# Altium schematic sheet MODEM_R2_1.SchDoc
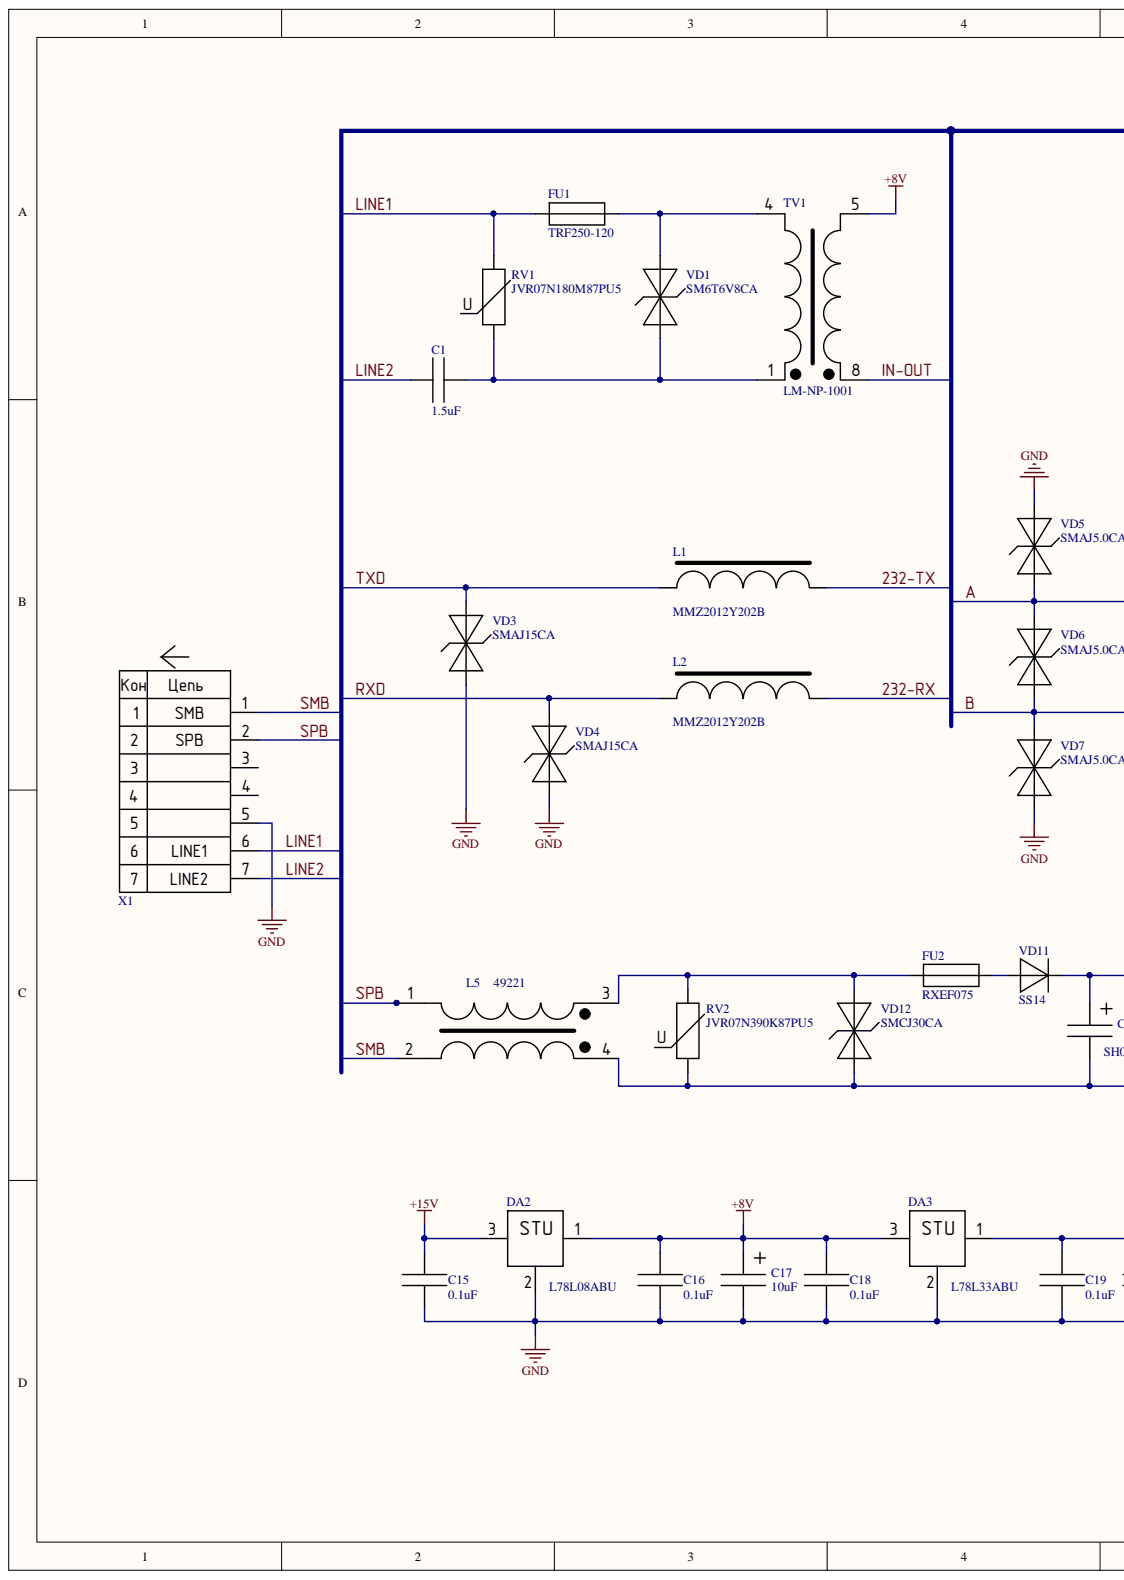
[diagram p0: MODEM_R2_1.SchDoc, left]
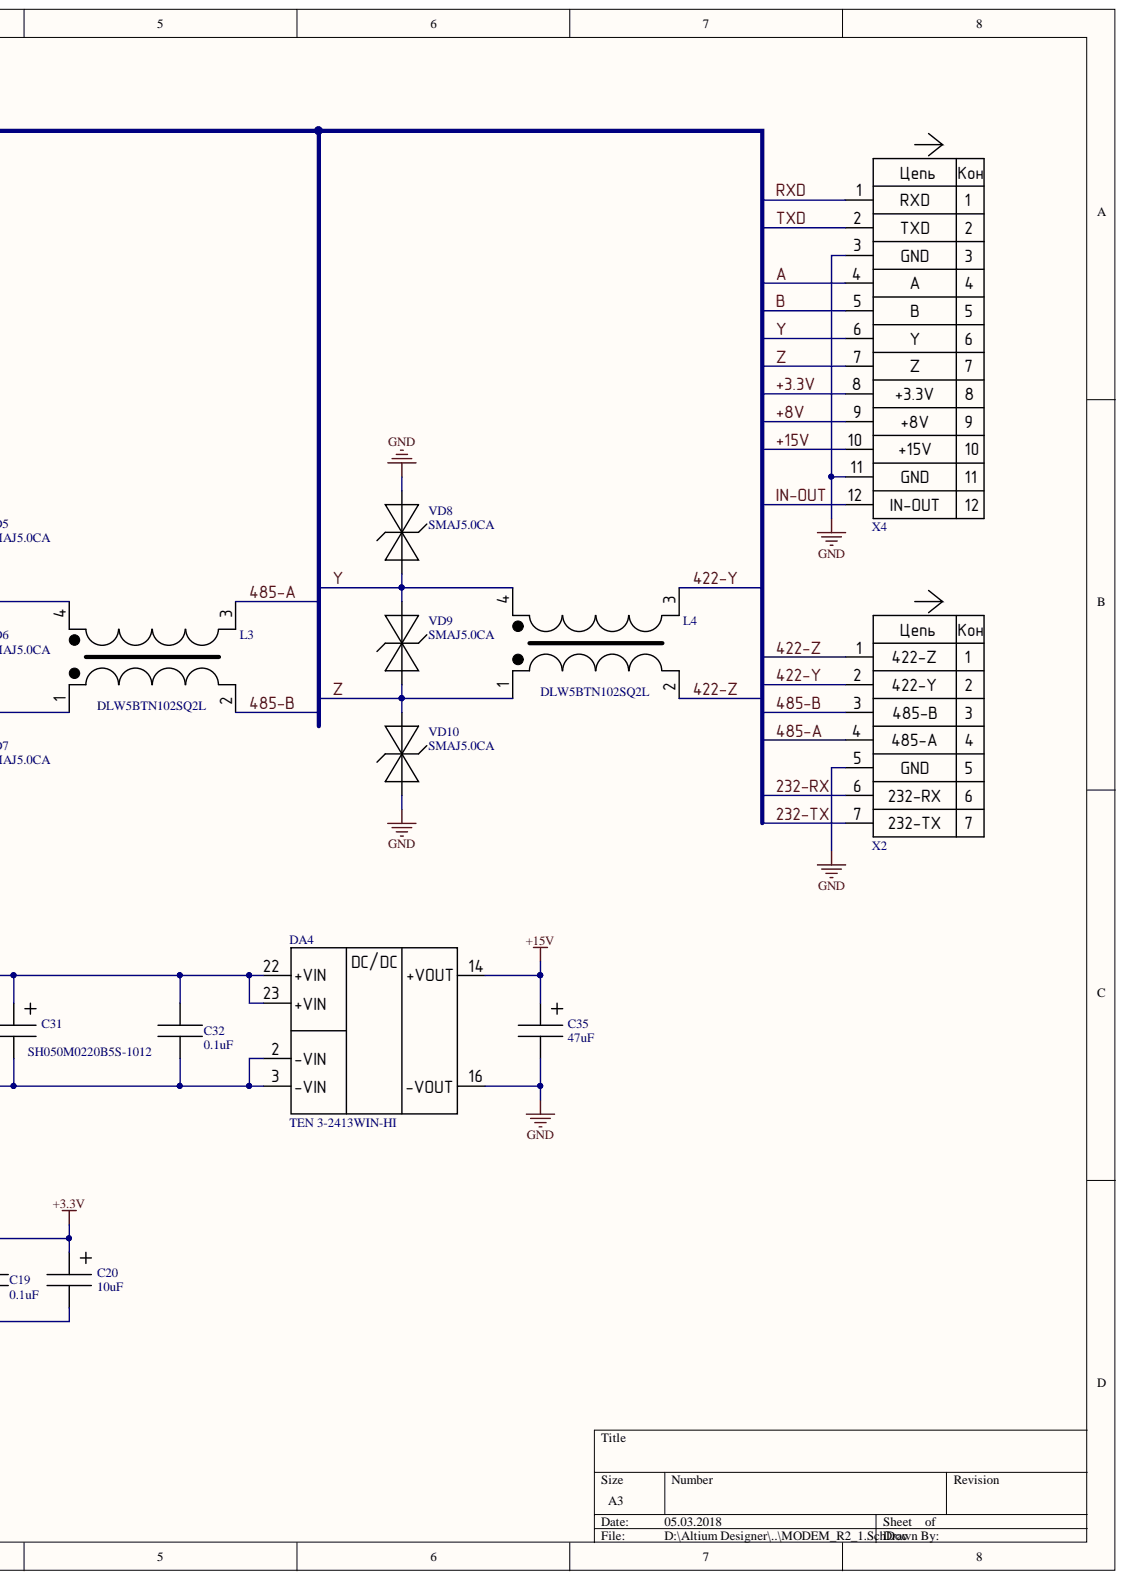
[diagram p1: MODEM_R2_1.SchDoc, right]

## Parts
C1  1.5uF  [TS02-22.5-h]
C15  0.1uF  [CC0805]
C16  0.1uF  [CC0805]
C17  10uF  [SMB]
C18  0.1uF  [CC0805]
C19  0.1uF  [CC0805]
C20  10uF  [SMB]
C31  SH050M0220B5S-1012  [SH050-1019]
C32  0.1uF  [CC0805]
C35  47uF  [SMD]
DA2  L78L08ABU  [SOT-89]
DA3  L78L33ABU  [SOT-89]
DA4  TEN 3-2413WIN-HI  [TEN3-2412WIN]
FU1  TRF250-120  [TRF250-120]
FU2  RXEF075  [RXEF075]
L1  MMZ2012Y202B  [MMZ2012Y202B]
L2  MMZ2012Y202B  [MMZ2012Y202B]
L3  DLW5BTN102SQ2L  [DLW5BTN]
L4  DLW5BTN102SQ2L  [DLW5BTN]
L5  49221  [49221]
RV1  JVR07N180M87PU5  [JVR07N330]
RV2  JVR07N390K87PU5  [JVR07N330]
TV1  LM-NP-1001  [LM-NP-1001]
VD1  SM6T6V8CA  [SM6T6V8CA]
VD3  SMAJ15CA  [SMAJ6.0CA]
VD4  SMAJ15CA  [SMAJ6.0CA]
VD5  SMAJ5.0CA  [SMAJ6.0CA]
VD6  SMAJ5.0CA  [SMAJ6.0CA]
VD7  SMAJ5.0CA  [SMAJ6.0CA]
VD8  SMAJ5.0CA  [SMAJ6.0CA]
VD9  SMAJ5.0CA  [SMAJ6.0CA]
VD10  SMAJ5.0CA  [SMAJ6.0CA]
VD11  SS14  [SS14]
VD12  SMCJ30CA  [SMCJ28A]
X1  8113_S/07  [8113_S/07]  (value not drawn)
X2  8113_S/07  [8113_S/07]  (value not drawn)
X4  PLS-12  [PLS-12]  (value not drawn)

## Nets
GND: C15.1 C16.1 C17.1 C18.1 C19.1 C20.1 C35.1 DA2.2 DA3.2 DA4.16(-VOUT) VD3.1 VD4.1 VD5.2 VD7.1 VD8.2 VD10.1 X1.5 X2.5(GND) X4.3(GND) X4.11(GND)
232-RX: L2.2 X2.6(232-RX)
232-TX: L1.2 X2.7(232-TX)
422-Y: L4.3 X2.2(422-Y)
422-Z: L4.2 X2.1(422-Z)
485-A: L3.3 X2.4(485-A)
485-B: L3.2 X2.3(485-B)
+3.3V: C19.2 C20.2 DA3.1 X4.8(+3.3V)
+8V: C16.2 C17.2 C18.2 DA2.1 DA3.3 TV1.5 X4.9(+8V)
+15V: C15.2 C35.2 DA2.3 DA4.14(+VOUT) X4.10(+15V)
A: L3.4 VD5.1 VD6.2 X4.4
B: L3.1 VD6.1 VD7.2 X4.5
IN-OUT: TV1.8 X4.12(IN-OUT)
LINE1: FU1.1 RV1.1 X1.6(LINE1)
LINE2: C1.1 X1.7(LINE2)
RXD: L2.1 VD4.2 X4.1(RXD)
SMB: L5.2 X1.1(SMB)
SPB: L5.1 X1.2(SPB)
TXD: L1.1 VD3.2 X4.2(TXD)
Y: L4.4 VD8.1 VD9.2 X4.6
Z: L4.1 VD9.1 VD10.2 X4.7
NetC1_2: C1.2 RV1.2 TV1.1 VD1.1
NetC31_1: C31.1 C32.1 DA4.2(-VIN) DA4.3(-VIN) L5.4 RV2.2 VD12.1
NetC31_2: C31.2 C32.2 DA4.22(+VIN) DA4.23(+VIN) VD11.2
NetFU1_2: FU1.2 TV1.4 VD1.2
NetFU2_1: FU2.1 L5.3 RV2.1 VD12.2
NetFU2_2: FU2.2 VD11.1
N00016:

Not connected: X1 3 4

## Library data of the parts
C1 C15 C16 C18 C19 C32 = CC1206: Component Kind: Standard; Library Name: CAPACITORS.SchLib
C17 C20 C31 C35 = SR-6: Component Kind: Standard; Library Name: CAPACITORS.SchLib
DA4 = TEN3-2412WIN: Component Kind: Standard; Library Name: Power Supplies.SchLib
FU2 = TRF250-120: Component Kind: Standard; Library Name: Discr.SchLib
L3 L4 = DLW31SN: Component Kind: Standard; Library Name: INDUCTORS.SchLib
RV1 RV2 = JVR14N361: Component Kind: Standard; Library Name: Discr.SchLib
VD3-VD10 = SMAJ6.0CA: Component Kind: Standard; Library Name: DIODES.SchLib
VD12 = SM6T6V8CA: Component Kind: Standard; Library Name: DIODES.SchLib
DA2 = L78L08ABU: Component Kind: Standard; Library Name: IC.SchLib
DA3 = L78L33ABU: Component Kind: Standard; Library Name: IC.SchLib
FU1 = TRF250-120: Component Kind: Standard; Library Name: Discr.SchLib
L1 L2 = MMZ2012Y202B: Component Kind: Standard; Library Name: INDUCTORS.SchLib
L5 = 49221: Component Kind: Standard; Library Name: INDUCTORS.SchLib
TV1 = LM-NP-1001: Component Kind: Standard; Library Name: INDUCTORS.SchLib
VD1 = SM6T6V8CA: Component Kind: Standard; Library Name: DIODES.SchLib
VD11 = SS14: Component Kind: Standard; Library Name: DIODES.SchLib
X1 X2 = 8113_S/07: Component Kind: Standard; Library Name: COMMUT.SchLib
X4 = PLS-12: Component Kind: Standard; Library Name: COMMUT.SchLib
